# Altium schematic sheet main.SchDoc(main)
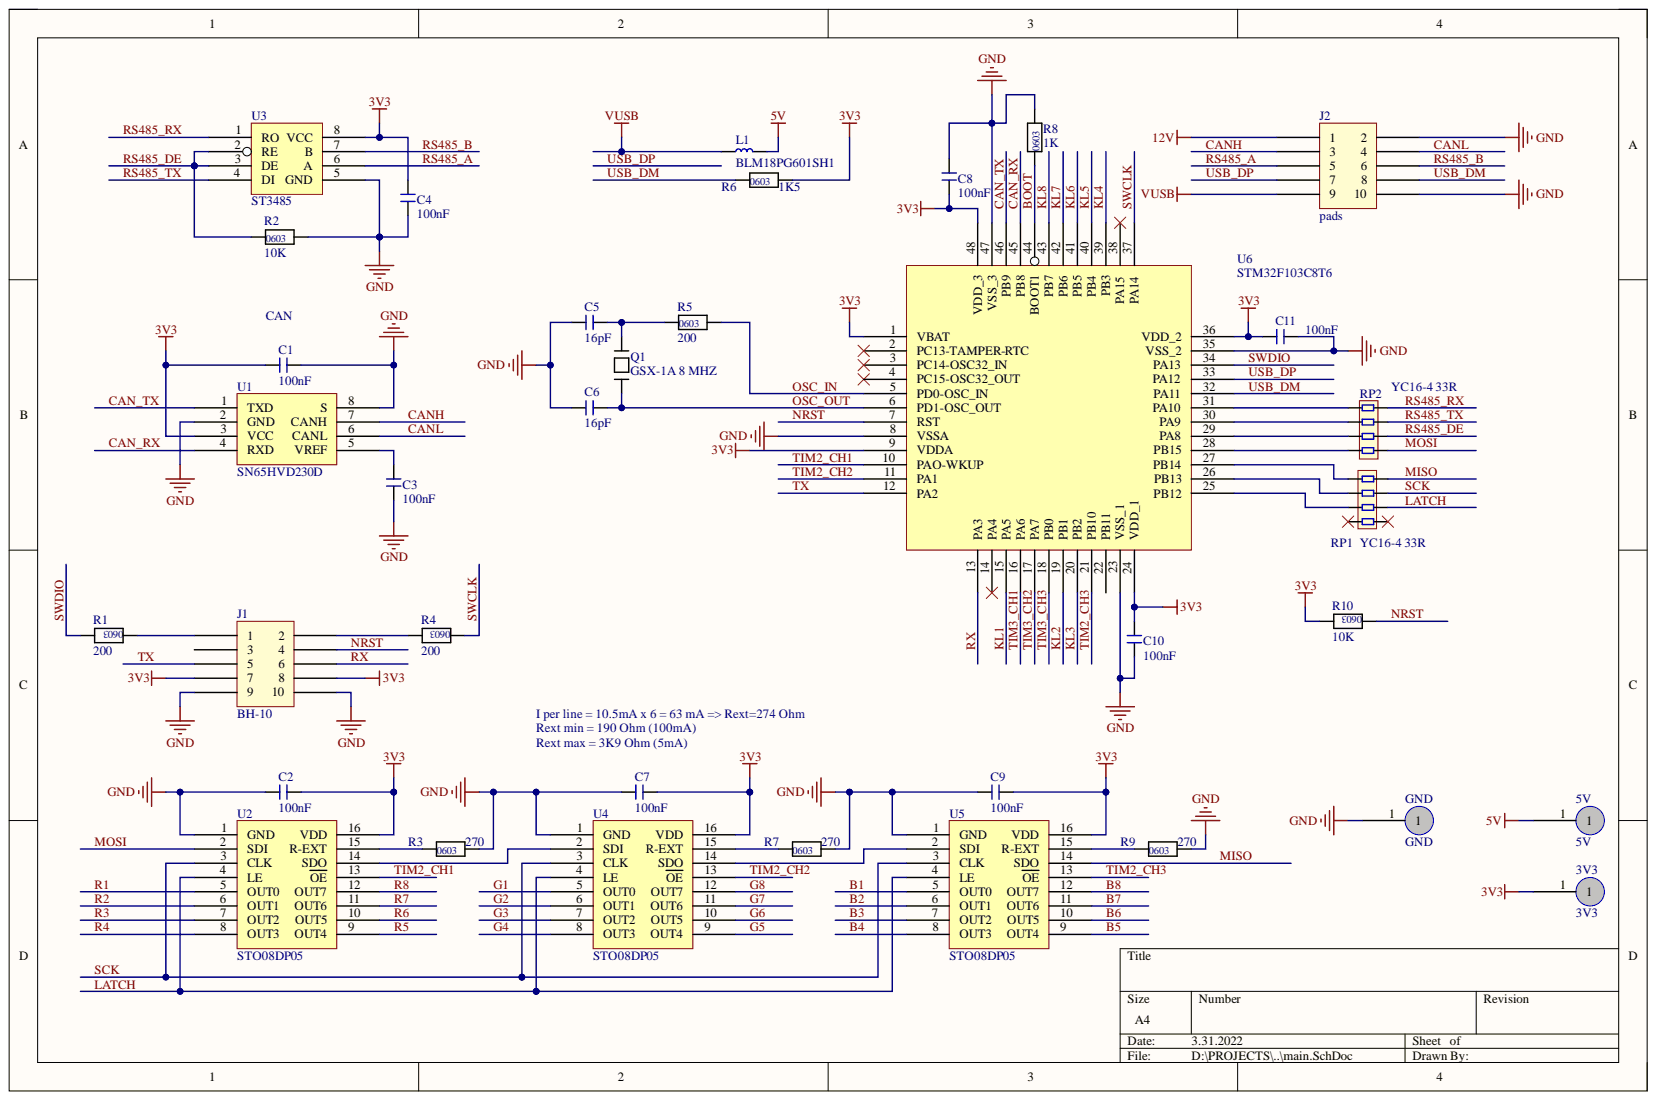
[diagram p0: main.SchDoc]

## Parts
3V3  3V3  [TestPoint]
5V  5V  [TestPoint]
C1  100nF  [CHIP_C_0603]
C2  100nF  [CHIP_C_0603]
C3  100nF  [CHIP_C_0603]
C4  100nF  [CHIP_C_0603]
C5  16pF  [CHIP_C_0603]
C6  16pF  [CHIP_C_0603]
C7  100nF  [CHIP_C_0603]
C8  100nF  [CHIP_C_0603]
C9  100nF  [CHIP_C_0603]
C10  100nF  [CHIP_C_0603]
C11  100nF  [CHIP_C_0603]
GND  GND  [TestPoint]
J1  BH-10  [BH-10]
J2  pads  [2x5 pads]
L1  BLM18PG601SH1  [CHIP_R_0603]
Q1  GSX-1A 8 MHZ  [KX-K]
R1  200  [CHIP_R_0603]
R2  10K  [CHIP_R_0603]
R3  270  [CHIP_R_0603]
R4  200  [CHIP_R_0603]
R5  200  [CHIP_R_0603]
R6  1K5  [CHIP_R_0603]
R7  270  [CHIP_R_0603]
R8  1K  [CHIP_R_0603]
R9  270  [CHIP_R_0603]
R10  10K  [CHIP_R_0603]
RP1  YC16-4 33R  [YC16-4]
RP2  YC16-4 33R  [YC16-4]
U1  SN65HVD230D  [SOIC8]
U2  STO08DP05  [SOIC16]
U3  ST3485  [SOIC8]
U4  STO08DP05  [SOIC16]
U5  STO08DP05  [SOIC16]
U6  STM32F103C8T6  [LQFP48]

## Nets
3V3: 3V3.1 C1.2 C2.2 C4.2 C7.2 C8.2 C9.2 C10.2 C11.2 J1.7 J1.8 R6.2 R10.2 U1.3(VCC) U2.16(VDD) U3.8(VCC) U4.16(VDD) U5.16(VDD) U6.1(VBAT) U6.9(VDDA) U6.24(VDD_1) U6.36(VDD_2) U6.48(VDD_3)
5V: 5V.1 L1.2
12V: J2.1
GND: C1.1 C2.1 C3.1 C4.1 C5.1 C6.1 C7.1 C8.1 C9.1 C10.1 C11.1 GND.1 J1.9 J1.10 J2.2 J2.10 R2.2 R3.2 R7.2 R8.2 R9.2 U1.2(GND) U1.8(S) U2.1(GND) U3.5(GND) U4.1(GND) U5.1(GND) U6.8(VSSA) U6.23(VSS_1) U6.35(VSS_2) U6.47(VSS_3)
VUSB: J2.9 L1.1
B1: U5.5(OUT0)
B2: U5.6(OUT1)
B3: U5.7(OUT2)
B4: U5.8(OUT3)
B5: U5.9(OUT4)
B6: U5.10(OUT5)
B7: U5.11(OUT6)
B8: U5.12(OUT7)
BOOT: R8.1 U6.44(BOOT1)
CAN_RX: U1.4(RXD) U6.45(PB8)
CAN_TX: U1.1(TXD) U6.46(PB9)
CANH: J2.3 U1.7(CANH)
CANL: J2.4 U1.6(CANL)
G1: U4.5(OUT0)
G2: U4.6(OUT1)
G3: U4.7(OUT2)
G4: U4.8(OUT3)
G5: U4.9(OUT4)
G6: U4.10(OUT5)
G7: U4.11(OUT6)
G8: U4.12(OUT7)
KL1: U6.15(PA5)
KL2: U6.19(PB1)
KL3: U6.20(PB2)
KL4: U6.39(PB3)
KL5: U6.40(PB4)
KL6: U6.41(PB5)
KL7: U6.42(PB6)
KL8: U6.43(PB7)
LATCH: RP1.2 U2.4(LE) U4.4(LE) U5.4(LE)
MISO: RP1.4 U5.14(SDO)
MOSI: RP2.5 U2.2(SDI)
NRST: J1.4 R10.1 U6.7(RST)
OSC_IN: R5.2 U6.5(PD0-OSC_IN)
OSC_OUT: C6.2 Q1.1 U6.6(PD1-OSC_OUT)
R1: U2.5(OUT0)
R2: U2.6(OUT1)
R3: U2.7(OUT2)
R4: U2.8(OUT3)
R5: U2.9(OUT4)
R6: U2.10(OUT5)
R7: U2.11(OUT6)
R8: U2.12(OUT7)
RS485_A: J2.5 U3.6(A)
RS485_B: J2.6 U3.7(B)
RS485_DE: R2.1 RP2.6 U3.2(RE) U3.3(DE)
RS485_RX: RP2.8 U3.1(RO)
RS485_TX: RP2.7 U3.4(DI)
RX: J1.6 U6.13(PA3)
SCK: RP1.3 U2.3(CLK) U4.3(CLK) U5.3(CLK)
SWCLK: R4.1 U6.37(PA14)
SWDIO: R1.2 U6.34(PA13)
TIM2_CH1: U2.13(OE) U6.10(PAO-WKUP)
TIM2_CH2: U4.13(OE) U6.11(PA1)
TIM2_CH3: U5.13(OE) U6.21(PB10)
TIM3_CH1: U6.16(PA6)
TIM3_CH2: U6.17(PA7)
TIM3_CH3: U6.18(PB0)
TX: J1.5 U6.12(PA2)
USB_DM: J2.8 R6.1 U6.32(PA11)
USB_DP: J2.7 U6.33(PA12)
NetC3_2: C3.2 U1.5(VREF)
NetC5_2: C5.2 Q1.2 R5.1
NetJ1_1: J1.1 R1.1
NetJ1_2: J1.2 R4.2
NetR3_1: R3.1 U2.15(R-EXT)
NetR7_1: R7.1 U4.15(R-EXT)
NetR9_1: R9.1 U5.15(R-EXT)
NetRP1_5: RP1.5 U6.27(PB14)
NetRP1_6: RP1.6 U6.26(PB13)
NetRP1_7: RP1.7 U6.25(PB12)
NetRP2_1: RP2.1 U6.31(PA10)
NetRP2_2: RP2.2 U6.30(PA9)
NetRP2_3: RP2.3 U6.29(PA8)
NetRP2_4: RP2.4 U6.28(PB15)
NetU2_14: U2.14(SDO) U4.2(SDI)
NetU4_14: U4.14(SDO) U5.2(SDI)

Not connected: J1 3; RP1 1 8; U6 2(PC13-TAMPER-RTC) 3(PC14-OSC32_IN) 4(PC15-OSC32_OUT) 14(PA4) 22(PB11) 38(PA15)

## Library data of the parts
3V3 5V GND = TestPoint: Component Kind: Standard; Library Name: Popular.SchLib
C1 C3 C4 = CHIP_C: Component Kind: Standard; Library Name: Popular.SchLib
C2 C5-C11 = CHIP_C: capacitor ceramic; Component Kind: Standard; Library Name: Popular.SchLib
J1 J2 = BH-10: IDC-10MS; Component Kind: Standard; Library Name: Popular.SchLib
L1 = BLM: Ferrite Beads; Component Kind: Standard; Library Name: Popular.SchLib
Q1 = Quartz: Component Kind: Standard; Library Name: Popular.SchLib
R1-R10 = RES_0603: Resistor; Component Kind: Standard; Library Name: Popular.SchLib
RP1 RP2 = YC16-4: Component Kind: Standard; Library Name: Popular.SchLib
U1 = TJA1050: Component Kind: Standard; Library Name: Popular.SchLib
U2 U4 U5 = STP08DP05: LED spi driver; Component Kind: Standard; Library Name: Popular.SchLib
U3 = ST3485: RS485 transeiver; Component Kind: Standard; Library Name: Popular.SchLib
U6 = STM32F103F: Component Kind: Standard; Library Name: STM32.SchLib
